# Altium schematic sheet [1]-MCU.SchDoc
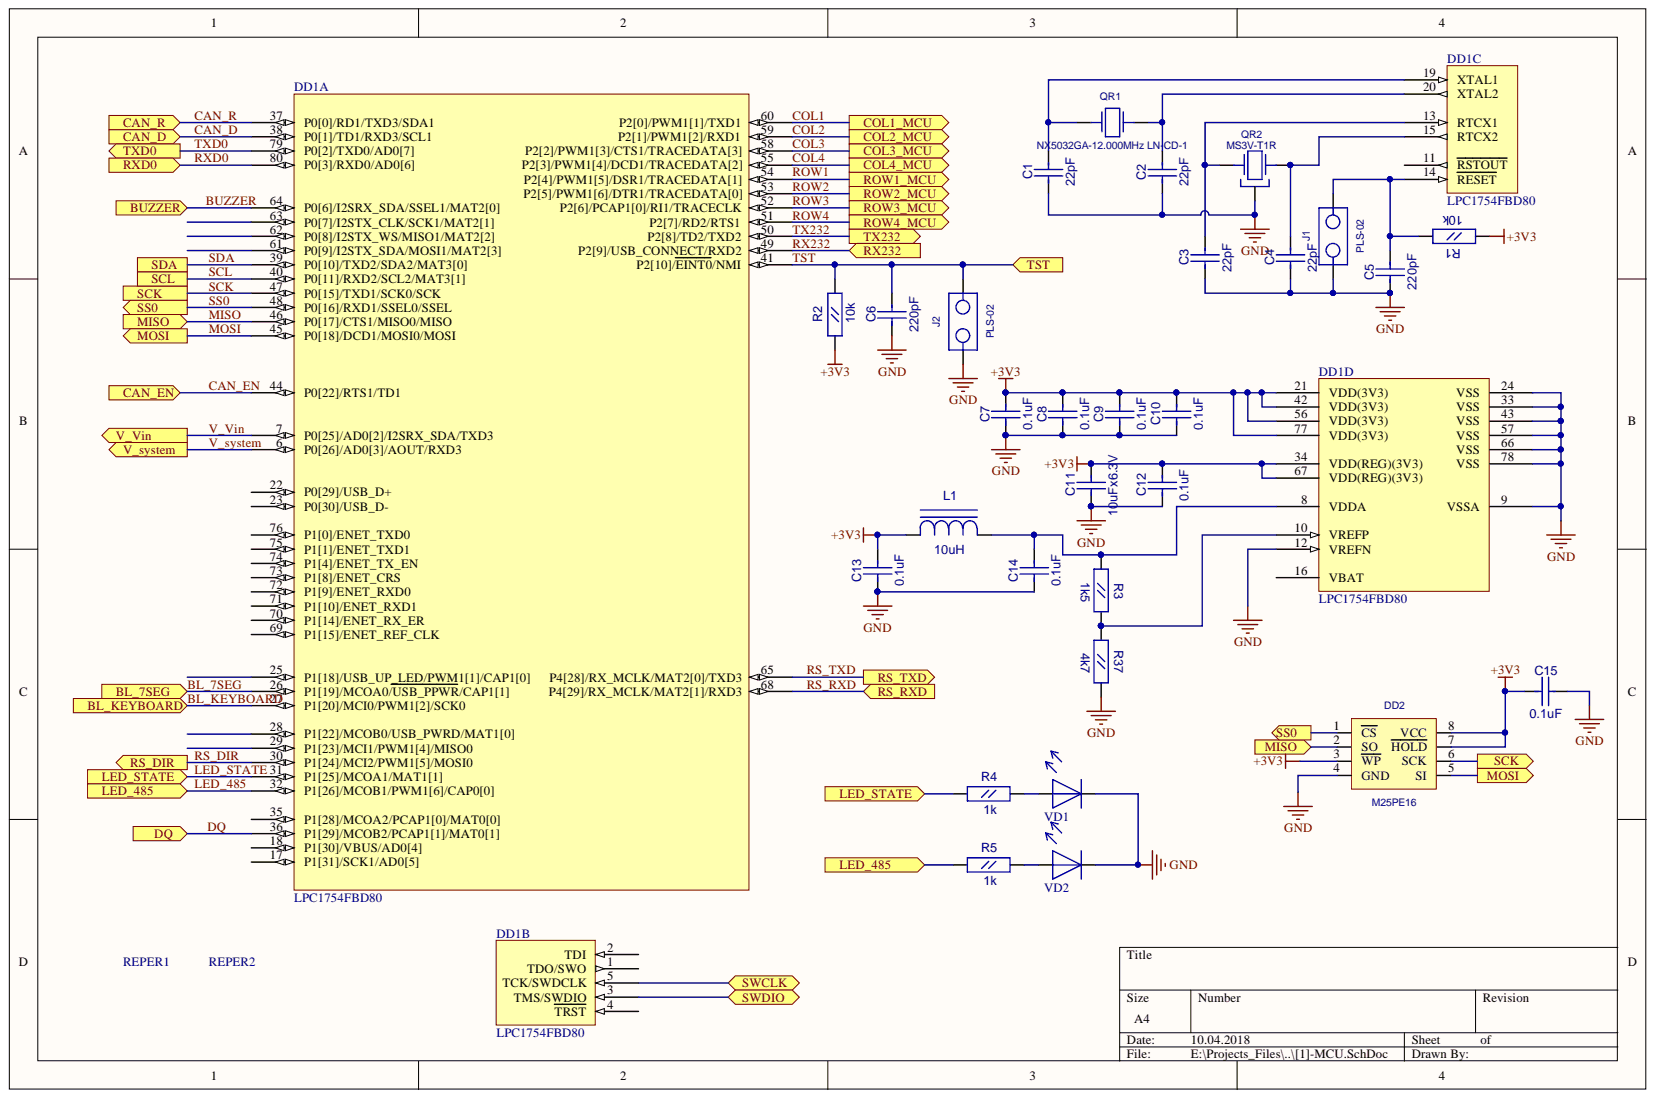
[diagram p0: [1]-MCU.SchDoc]

## Parts
C1  22pF  [CC0603]
C2  22pF  [CC0603]
C3  22pF  [CC0603]
C4  22pF  [CC0603]
C5  220pF  [CC0603]
C6  220pF  [CC0603]
C7  0.1uF  [CC0603]
C8  0.1uF  [CC0603]
C9  0.1uF  [CC0603]
C10  0.1uF  [CC0603]
C11  10uFx6.3V  [CC0805]
C12  0.1uF  [CC0603]
C13  0.1uF  [CC0603]
C14  0.1uF  [CC0603]
C15  0.1uF  [CC0603]
DD1  LPC1754FBD80  [TQFP-80_0.5]  (parts A,B,C,D)
DD2  M25PE16  [EIAJ_SO8]
J1  PLS-02  [PLS-02]
J2  PLS-02  [PLS-02]
L1  10uH  [L0603]
QR1  NX5032GA-12.000MHz LN-CD-1  [AC5032_2]
QR2  MS3V-T1R  [MS3V-T1R]
R1  10k  [RC0603]
R2  10k  [RC0603]
R3  1k5  [RC0603]
R4  1k  [RC0603]
R5  1k  [RC0603]
R37  4k7  [RC0603]
REPER1  REPER1.2  [REPER1.2]  (value not drawn)
REPER2  REPER1.2  [REPER1.2]  (value not drawn)
VD1  LED_0805  [LED0805]  (value not drawn)
VD2  LED_0805  [LED0805]  (value not drawn)

## Ports
BL_7SEG, BL_KEYBOARD, BUZZER, CAN_D, CAN_EN, CAN_R, COL1_MCU, COL2_MCU, COL3_MCU, COL4_MCU, DQ, LED_485, LED_STATE, MISO, MOSI, ROW1_MCU, ROW2_MCU, ROW3_MCU, ROW4_MCU, RS_DIR, RS_RXD, RS_TXD, RX232, RXD0, SCK, SCL, SDA, SS0, SWCLK, SWDIO, TST, TX232, TXD0, V_system, V_Vin

## Nets
+3V3: C7.2 C8.2 C9.2 C10.2 C11.2 C12.2 C13.2 C15.1 DD1.21(VDD(3V3)) DD1.34(VDD(REG)(3V3)) DD1.42(VDD(3V3)) DD1.56(VDD(3V3)) DD1.67(VDD(REG)(3V3)) DD1.77(VDD(3V3)) DD2.3(WP) DD2.7(HOLD) DD2.8(VCC) L1.1 R1.1 R2.1
GND: C1.1 C2.1 C3.1 C4.1 C5.1 C6.1 C7.1 C8.1 C9.1 C10.1 C11.1 C12.1 C13.1 C14.1 C15.2 DD1.9(VSSA) DD1.12(VREFN) DD1.24(VSS) DD1.33(VSS) DD1.43(VSS) DD1.57(VSS) DD1.66(VSS) DD1.78(VSS) DD2.4(GND) J1.1 J2.1 QR2.3 R37.2 VD1.2 VD2.2
BL_7SEG: DD1.26(P1[19]/MCOA0/USB_PPWR/CAP1[1]) ; port BL_7SEG
BL_KEYBOARD: DD1.27(P1[20]/MCI0/PWM1[2]/SCK0) ; port BL_KEYBOARD
BUZZER: DD1.64(P0[6]/I2SRX_SDA/SSEL1/MAT2[0]) ; port BUZZER
CAN_D: DD1.38(P0[1]/TD1/RXD3/SCL1) ; port CAN_D
CAN_EN: DD1.44(P0[22]/RTS1/TD1) ; port CAN_EN
CAN_R: DD1.37(P0[0]/RD1/TXD3/SDA1) ; port CAN_R
COL1: DD1.60(P2[0]/PWM1[1]/TXD1) ; port COL1_MCU
COL2: DD1.59(P2[1]/PWM1[2]/RXD1) ; port COL2_MCU
COL3: DD1.58(P2[2]/PWM1[3]/CTS1/TRACEDATA[3]) ; port COL3_MCU
COL4: DD1.55(P2[3]/PWM1[4]/DCD1/TRACEDATA[2]) ; port COL4_MCU
DQ: DD1.36(P1[29]/MCOB2/PCAP1[1]/MAT0[1]) ; port DQ
LED_485: DD1.32(P1[26]/MCOB1/PWM1[6]/CAP0[0]) R5.1 ; port LED_485
LED_STATE: DD1.31(P1[25]/MCOA1/MAT1[1]) R4.1 ; port LED_STATE
MISO: DD1.46(P0[17]/CTS1/MISO0/MISO) DD2.2(SO) ; port MISO
MOSI: DD1.45(P0[18]/DCD1/MOSI0/MOSI) DD2.5(SI) ; port MOSI
ROW1: DD1.54(P2[4]/PWM1[5]/DSR1/TRACEDATA[1]) ; port ROW1_MCU
ROW2: DD1.53(P2[5]/PWM1[6]/DTR1/TRACEDATA[0]) ; port ROW2_MCU
ROW3: DD1.52(P2[6]/PCAP1[0]/RI1/TRACECLK) ; port ROW3_MCU
ROW4: DD1.51(P2[7]/RD2/RTS1) ; port ROW4_MCU
RS_DIR: DD1.30(P1[24]/MCI2/PWM1[5]/MOSI0) ; port RS_DIR
RS_RXD: DD1.68(P4[29]/RX_MCLK/MAT2[1]/RXD3) ; port RS_RXD
RS_TXD: DD1.65(P4[28]/RX_MCLK/MAT2[0]/TXD3) ; port RS_TXD
RX232: DD1.49(P2[9]/USB_CONNECT/RXD2) ; port RX232
RXD0: DD1.80(P0[3]/RXD0/AD0[6]) ; port RXD0
SCK: DD1.47(P0[15]/TXD1/SCK0/SCK) DD2.6(SCK) ; port SCK
SCL: DD1.40(P0[11]/RXD2/SCL2/MAT3[1]) ; port SCL
SDA: DD1.39(P0[10]/TXD2/SDA2/MAT3[0]) ; port SDA
SS0: DD1.48(P0[16]/RXD1/SSEL0/SSEL) DD2.1(CS) ; port SS0
SWCLK: DD1.5(TCK/SWDCLK) ; port SWCLK
SWDIO: DD1.3(TMS/SWDIO) ; port SWDIO
TST: C6.2 DD1.41(P2[10]/EINT0/NMI) J2.2 R2.2 ; port TST
TX232: DD1.50(P2[8]/TD2/TXD2) ; port TX232
TXD0: DD1.79(P0[2]/TXD0/AD0[7]) ; port TXD0
V_system: DD1.6(P0[26]/AD0[3]/AOUT/RXD3) ; port V_system
V_Vin: DD1.7(P0[25]/AD0[2]/I2SRX_SDA/TXD3) ; port V_Vin
NetC1_2: C1.2 DD1.19(XTAL1) QR1.1
NetC2_2: C2.2 DD1.20(XTAL2) QR1.2
NetC3_2: C3.2 DD1.13(RTCX1) QR2.2
NetC4_2: C4.2 DD1.15(RTCX2) QR2.1
NetC5_2: C5.2 DD1.14(RESET) J1.2 R1.2
NetC14_2: C14.2 DD1.8(VDDA) L1.2 R3.1
NetDD1_10: DD1.10(VREFP) R3.2 R37.1
NetR4_2: R4.2 VD1.1
NetR5_2: R5.2 VD2.1

Not connected: DD1 1(TDO/SWO) 2(TDI) 4(TRST) 11(RSTOUT) 16(VBAT) 17(P1[31]/SCK1/AD0[5]) 18(P1[30]/VBUS/AD0[4]) 22(P0[29]/USB_D+) 23(P0[30]/USB_D-) 25(P1[18]/USB_UP_LED/PWM1[1]/CAP1[0]) 28(P1[22]/MCOB0/USB_PWRD/MAT1[0]) 29(P1[23]/MCI1/PWM1[4]/MISO0) 35(P1[28]/MCOA2/PCAP1[0]/MAT0[0]) 61(P0[9]/I2STX_SDA/MOSI1/MAT2[3]) 62(P0[8]/I2STX_WS/MISO1/MAT2[2]) 63(P0[7]/I2STX_CLK/SCK1/MAT2[1]) 69(P1[15]/ENET_REF_CLK) 70(P1[14]/ENET_RX_ER) 71(P1[10]/ENET_RXD1) 72(P1[9]/ENET_RXD0) 73(P1[8]/ENET_CRS) 74(P1[4]/ENET_TX_EN) 75(P1[1]/ENET_TXD1) 76(P1[0]/ENET_TXD0)

## Library data of the parts
C1-C10 C12-C15 = CC0603: Component Kind: Standard; Description: CC0603; Library Name: gprs_modem_2.SchLib
  C1-C4: Value: 22pF
  C5 C6: Value: 220pF
  C7-C10 C12-C15: Value: 0.1uF
C11 = CC0805: Component Kind: Standard; Description: CC0805; Value: 10uFx6.3V; Library Name: gprs_modem_2.SchLib
DD1 = LPC1754FBD80: Cortex -M3 32-Bit Microcontroller, 128KB Flash, 64KB RAM, 800-Lead LQFP; Component Kind: Standard; ComponentLink1Description: Manufacturer Link; ComponentLink1URL: http://www.nxp.com/; Library Name: gprs_modem_2.SchLib
DD2 = S25FL016A0LMFI01: Component Kind: Standard; Description: S25FL016A0LMFI01; Value: M25PE16; Library Name: gprs_modem_2.SchLib
L1 = L0603: Component Kind: Standard; Description: L0603; Value: 10uH; Library Name: gprs_modem_2.SchLib
QR1 = AC5032_2: AC5032/2; Component Kind: Standard; Value: NX5032GA-12.000MHz LN-CD-1; Library Name: gprs_modem_2.SchLib
QR2 = MS3V-T1R: CRYSTAL_32768 Hz; Component Kind: Standard; Library Name: gprs_modem_2.SchLib
R1-R5 R37 = RC0603: Component Kind: Standard; Description: RC0603; Library Name: gprs_modem_2.SchLib
  R1 R2: Value: 10k
  R3: Value: 1k5
  R4 R5: Value: 1k
  R37: Value: 4k7
J1 J2 = PLS-02: Component Kind: Standard; Description: PLS-02; Library Name: gprs_modem_2.SchLib
REPER1 REPER2 = REPER1.2: Component Kind: Standard; Description: REPER1.2; Library Name: gprs_modem.SchLib
VD1 VD2 = LED_0805: Component Kind: Standard; Value: LED_0805; Library Name: gprs_modem_2.SchLib
